# Altium schematic sheet Serial Monitor UI Processor Board Schematic.SchDoc("Serial Monitor UI Processor Board Schematic")
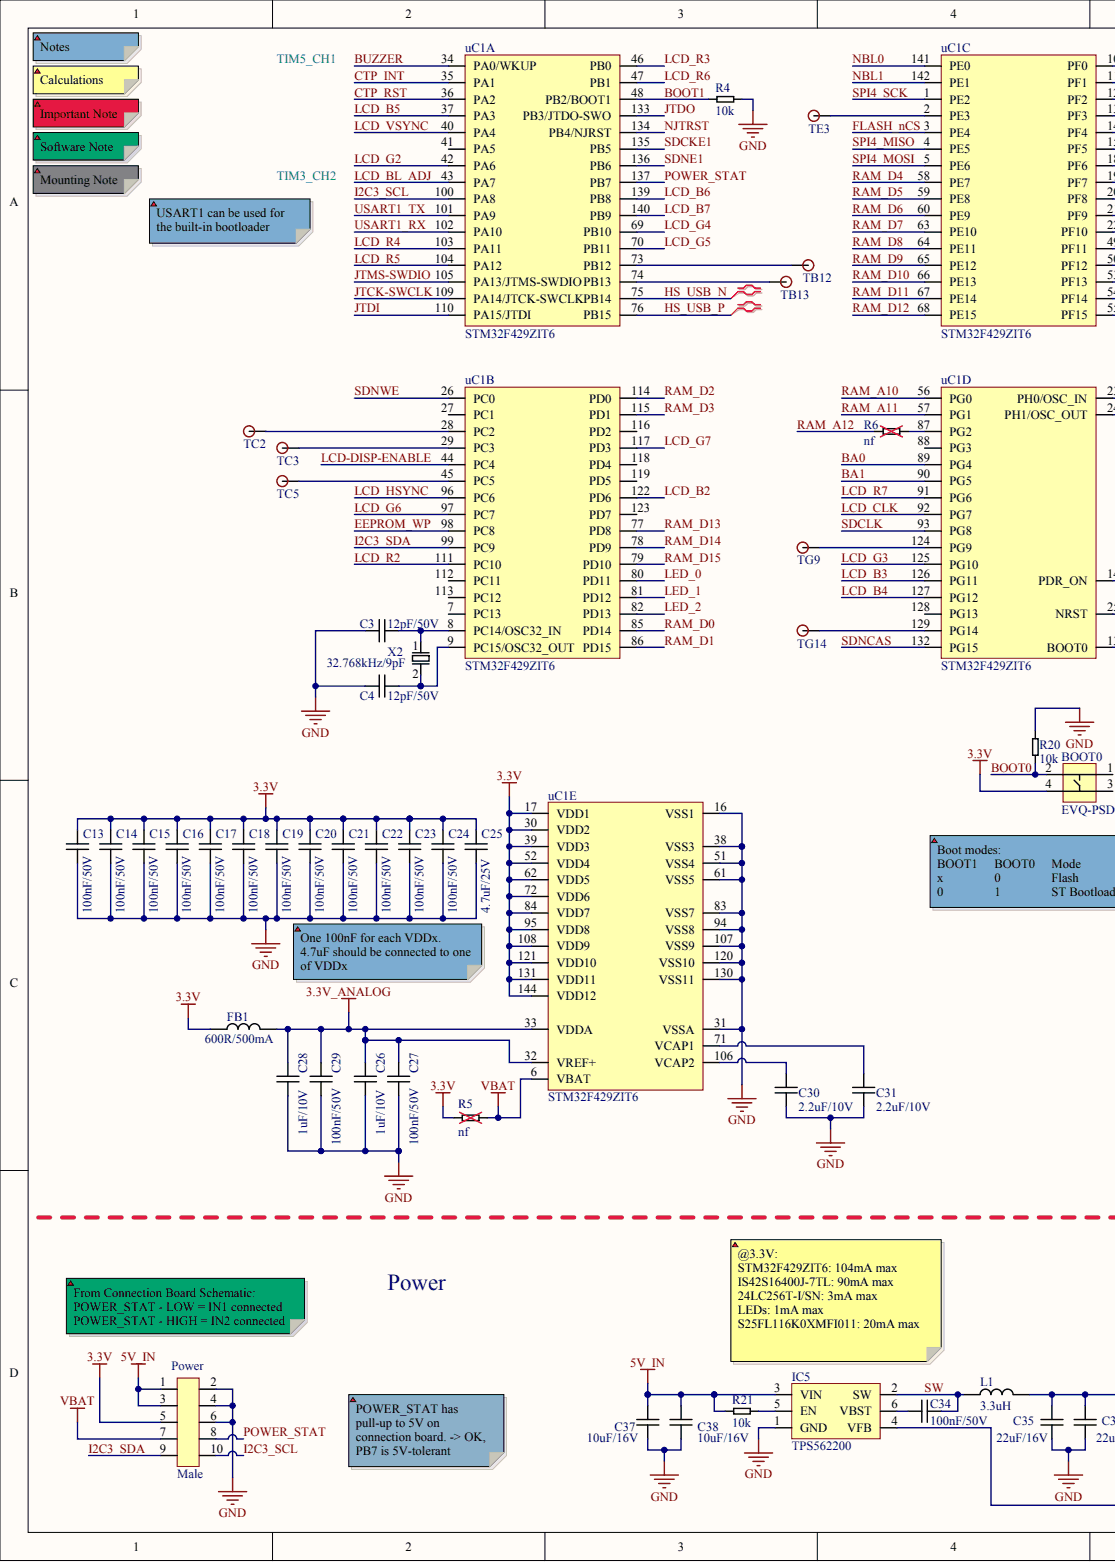
[diagram p0: Serial Monitor UI Processor Board Schematic.SchDoc, left]
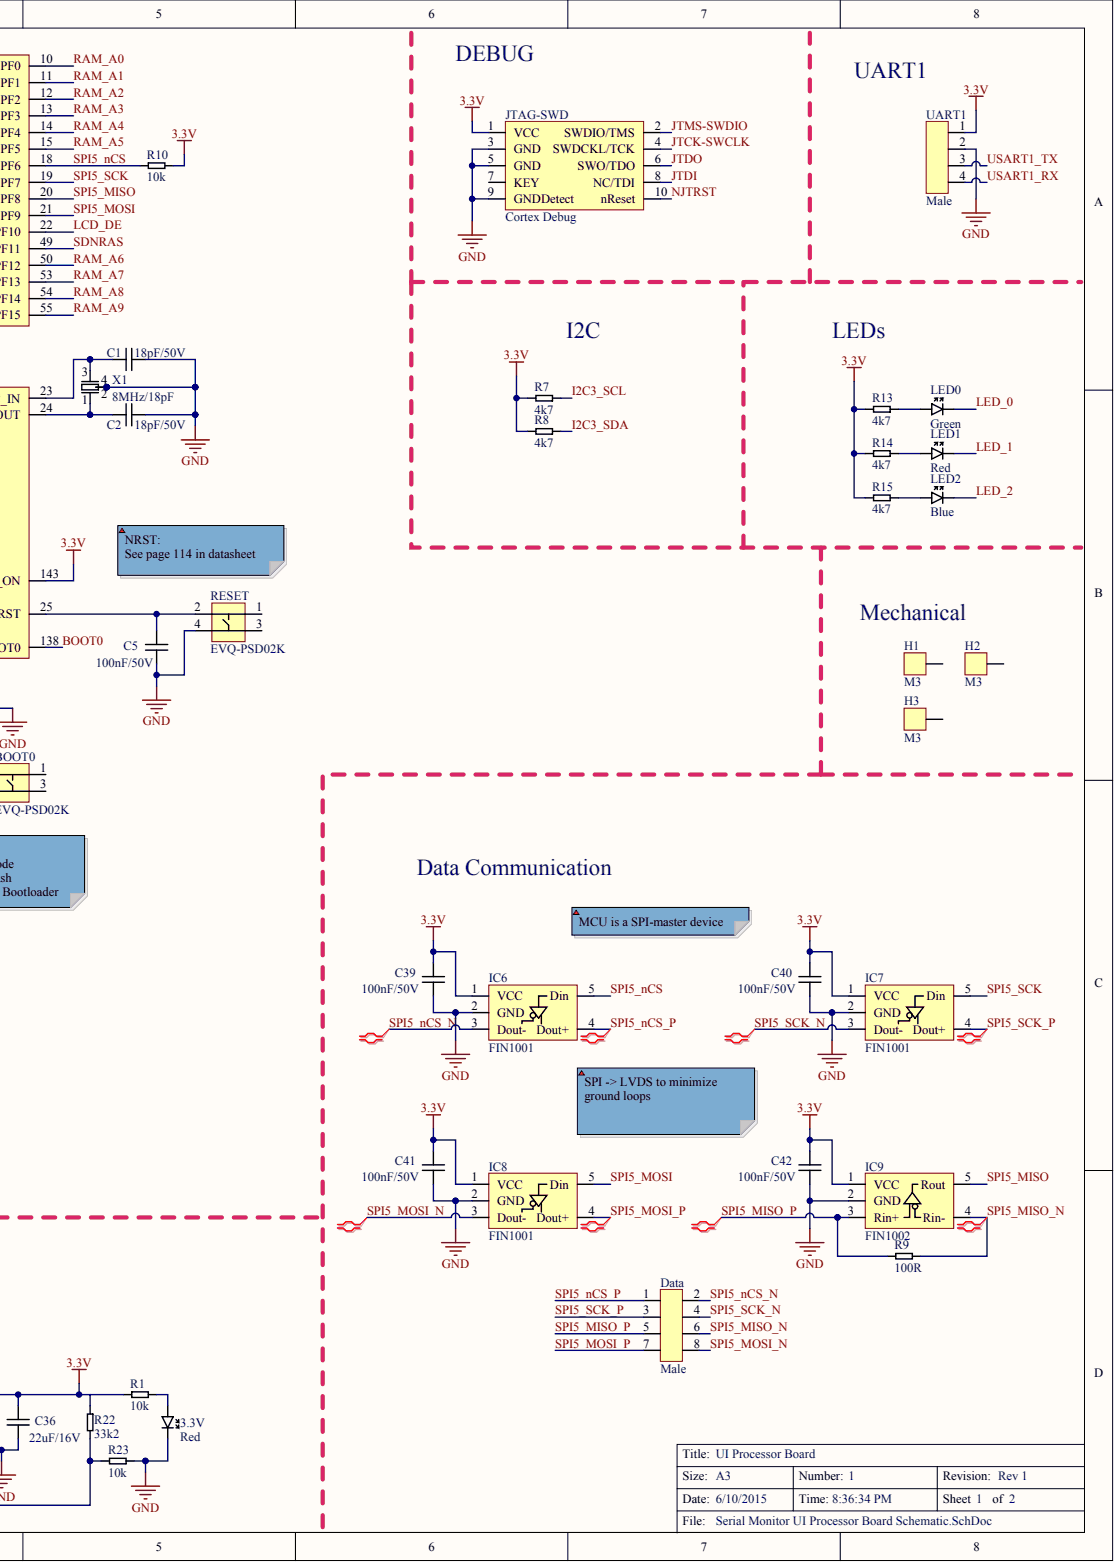
[diagram p1: Serial Monitor UI Processor Board Schematic.SchDoc, right]

## Parts
3.3V  value Red  (hidden comment: LED_0603_Red)
BOOT0  value EVQ-PSD02K  Part No.: EVQ-PSD02K  (hidden comment: Tact Switch EVQ-PSD02K)
C1  value 18pF/50V  [0603]  (hidden comment: Cap_0603_18pF/50V)
C2  value 18pF/50V  [0603]  (hidden comment: Cap_0603_18pF/50V)
C3  value 12pF/50V  [0603]  (hidden comment: Cap_0603_12pF/50V)
C4  value 12pF/50V  [0603]  (hidden comment: Cap_0603_12pF/50V)
C5  value 100nF/50V  [0603]  (hidden comment: Cap_0603_100nF/50V_X7R)
C13  value 100nF/50V  [0603]  (hidden comment: Cap_0603_100nF/50V_X7R)
C14  value 100nF/50V  [0603]  (hidden comment: Cap_0603_100nF/50V_X7R)
C15  value 100nF/50V  [0603]  (hidden comment: Cap_0603_100nF/50V_X7R)
C16  value 100nF/50V  [0603]  (hidden comment: Cap_0603_100nF/50V_X7R)
C17  value 100nF/50V  [0603]  (hidden comment: Cap_0603_100nF/50V_X7R)
C18  value 100nF/50V  [0603]  (hidden comment: Cap_0603_100nF/50V_X7R)
C19  value 100nF/50V  [0603]  (hidden comment: Cap_0603_100nF/50V_X7R)
C20  value 100nF/50V  [0603]  (hidden comment: Cap_0603_100nF/50V_X7R)
C21  value 100nF/50V  [0603]  (hidden comment: Cap_0603_100nF/50V_X7R)
C22  value 100nF/50V  [0603]  (hidden comment: Cap_0603_100nF/50V_X7R)
C23  value 100nF/50V  [0603]  (hidden comment: Cap_0603_100nF/50V_X7R)
C24  value 100nF/50V  [0603]  (hidden comment: Cap_0603_100nF/50V_X7R)
C25  value 4.7uF/25V  [0805]  (hidden comment: Cap_0805_4.7uF/25V)
C26  value 1uF/10V  [0603]  (hidden comment: Cap_0603_1uF/10V)
C27  value 100nF/50V  [0603]  (hidden comment: Cap_0603_100nF/50V_X7R)
C28  value 1uF/10V  [0603]  (hidden comment: Cap_0603_1uF/10V)
C29  value 100nF/50V  [0603]  (hidden comment: Cap_0603_100nF/50V_X7R)
C30  value 2.2uF/10V  [0805]  (hidden comment: Cap_0805_2.2uF/10V)
C31  value 2.2uF/10V  [0805]  (hidden comment: Cap_0805_2.2uF/10V)
C34  value 100nF/50V  [0603]  (hidden comment: Cap_0603_100nF/50V)
C35  value 22uF/16V  [0805]  (hidden comment: Cap_0805_22uF/16V)
C36  value 22uF/16V  [0805]  (hidden comment: Cap_0805_22uF/16V)
C37  value 10uF/16V  [0805]  (hidden comment: Cap_0805_10uF/16V)
C38  value 10uF/16V  [0805]  (hidden comment: Cap_0805_10uF/16V)
C39  value 100nF/50V  [0603]  (hidden comment: Cap_0603_100nF/50V)
C40  value 100nF/50V  [0603]  (hidden comment: Cap_0603_100nF/50V)
C41  value 100nF/50V  [0603]  (hidden comment: Cap_0603_100nF/50V)
C42  value 100nF/50V  [0603]  (hidden comment: Cap_0603_100nF/50V)
Data  value Male  (hidden comment: Connector 2x4)
FB1  value 600R/500mA  [0603]  (hidden comment: Ferrite_Bead_0603_600R_500mA)
H1  value M3  (hidden comment: M3_SCREWHOLE)
H2  value M3  (hidden comment: M3_SCREWHOLE)
H3  value M3  (hidden comment: M3_SCREWHOLE)
IC5  TPS562200  [SOT-23-6]
IC6  FIN1001  [SOT-23-5]
IC7  FIN1001  [SOT-23-5]
IC8  FIN1001  [SOT-23-5]
IC9  FIN1002  [SOT-23-5]
JTAG-SWD  value Cortex Debug  (hidden comment: Connector 2x5 Cortex Debug)
L1  value 3.3uH  [SMD SRN4028 4.8x4.8mm]  (hidden comment: Inductor_SRR4028_3.3uH)
LED0  value Green  (hidden comment: LED_0603_Green)
LED1  value Red  (hidden comment: LED_0603_Red)
LED2  value Blue  (hidden comment: LED_0603_Blue)
Power  value Male  (hidden comment: Connector 2x5)
R1  value 10k  [0603]  (hidden comment: Res_0603_10k)
R4  value 10k  [0603]  (hidden comment: Res_0603_10k)
R5  value nf  [0603]  (hidden comment: Res_0603_nf)
R6  value nf  [0603]  (hidden comment: Res_0603_nf)
R7  value 4k7  [0603]  (hidden comment: Res_0603_4k7)
R8  value 4k7  [0603]  (hidden comment: Res_0603_4k7)
R9  value 100R  [0603]  (hidden comment: Res_0603_100R)
R10  value 10k  [0603]  (hidden comment: Res_0603_10k)
R13  value 4k7  [0603]  (hidden comment: Res_0603_4k7)
R14  value 4k7  [0603]  (hidden comment: Res_0603_4k7)
R15  value 4k7  [0603]  (hidden comment: Res_0603_4k7)
R20  value 10k  [0603]  (hidden comment: Res_0603_10k)
R21  value 10k  [0603]  (hidden comment: Res_0603_10k)
R22  value 33k2  [0603]  (hidden comment: Res_0603_33k2)
R23  value 10k  [0603]  (hidden comment: Res_0603_10k)
RESET  value EVQ-PSD02K  Part No.: EVQ-PSD02K  (hidden comment: Tact Switch EVQ-PSD02K)
TB12  Testpad  (value not drawn)
TB13  Testpad  (value not drawn)
TC2  Testpad  (value not drawn)
TC3  Testpad  (value not drawn)
TC5  Testpad  (value not drawn)
TE3  Testpad  (value not drawn)
TG9  Testpad  (value not drawn)
TG14  Testpad  (value not drawn)
UART1  value Male  (hidden comment: Connector 1x4)
uC1  STM32F429ZIT6  [LQFP-144]  (parts A,B,C,D,E)
X1  value 8MHz/18pF  [4-pin SMD 5x3mm]  (hidden comment: 7B-8.000MAAJ-T)
X2  value 32.768kHz/9pF  [2-SMD 3.5x1.5mm]  (hidden comment: ABS07-32.768KHZ-9-T)

## Nets
3.3V: BOOT0.4 C13.2 C14.2 C15.2 C16.2 C17.2 C18.2 C19.2 C20.2 C21.2 C22.2 C23.2 C24.2 C25.2 C35.2 C36.2 C39.2 C40.2 C41.2 C42.2 FB1.1 IC6.1(VCC) IC7.1(VCC) IC8.1(VCC) IC9.1(VCC) JTAG-SWD.1(VCC) L1.2 Power.5 R1.2 R5.1 R7.1 R8.1 R10.1 R13.1 R14.1 R15.1 R22.2 UART1.1 uC1.17(VDD1) uC1.30(VDD2) uC1.39(VDD3) uC1.52(VDD4) uC1.62(VDD5) uC1.72(VDD6) uC1.84(VDD7) uC1.95(VDD8) uC1.108(VDD9) uC1.121(VDD10) uC1.131(VDD11) uC1.143(PDR_ON) uC1.144(VDD12)
3.3V_ANALOG: C26.2 C27.2 C28.2 C29.2 FB1.2 uC1.32(VREF+) uC1.33(VDDA)
5V_IN: C37.2 C38.2 IC5.3(VIN) Power.1 Power.3 R21.2
GND: 3.3V.CATHODE C1.2 C2.2 C3.2 C4.2 C5.1 C13.1 C14.1 C15.1 C16.1 C17.1 C18.1 C19.1 C20.1 C21.1 C22.1 C23.1 C24.1 C25.1 C26.1 C27.1 C28.1 C29.1 C30.1 C31.1 C35.1 C36.1 C37.1 C38.1 C39.1 C40.1 C41.1 C42.1 IC5.1(GND) IC6.2(GND) IC7.2(GND) IC8.2(GND) IC9.2(GND) JTAG-SWD.3(GND) JTAG-SWD.5(GND) JTAG-SWD.9(GNDDetect) Power.2 Power.4 Power.6 R4.2 R20.1 R23.2 RESET.4 UART1.2 uC1.16(VSS1) uC1.31(VSSA) uC1.38(VSS3) uC1.51(VSS4) uC1.61(VSS5) uC1.83(VSS7) uC1.94(VSS8) uC1.107(VSS9) uC1.120(VSS10) uC1.130(VSS11) X1.2 X1.4
VBAT: Power.7 R5.2 uC1.6(VBAT)
BA0: uC1.89(PG4)
BA1: uC1.90(PG5)
BOOT0: BOOT0.2 R20.2 uC1.138(BOOT0)
BOOT1: R4.1 uC1.48(PB2/BOOT1)
BUZZER: uC1.34(PA0/WKUP)
CTP_INT: uC1.35(PA1)
CTP_RST: uC1.36(PA2)
EEPROM_WP: uC1.98(PC8)
FLASH_NCS: uC1.3(PE4)
HS_USB_N: uC1.75(PB14)
HS_USB_P: uC1.76(PB15)
I2C3_SCL: Power.10 R7.2 uC1.100(PA8)
I2C3_SDA: Power.9 R8.2 uC1.99(PC9)
JTCK-SWCLK: JTAG-SWD.4(SWDCKL/TCK) uC1.109(PA14/JTCK-SWCLK)
JTDI: JTAG-SWD.8(NC/TDI) uC1.110(PA15/JTDI)
JTDO: JTAG-SWD.6(SWO/TDO) uC1.133(PB3/JTDO-SWO)
JTMS-SWDIO: JTAG-SWD.2(SWDIO/TMS) uC1.105(PA13/JTMS-SWDIO)
LCD-DISP-ENABLE: uC1.44(PC4)
LCD_B2: uC1.122(PD6)
LCD_B3: uC1.126(PG11)
LCD_B4: uC1.127(PG12)
LCD_B5: uC1.37(PA3)
LCD_B6: uC1.139(PB8)
LCD_B7: uC1.140(PB9)
LCD_BL_ADJ: uC1.43(PA7)
LCD_CLK: uC1.92(PG7)
LCD_DE: uC1.22(PF10)
LCD_G2: uC1.42(PA6)
LCD_G3: uC1.125(PG10)
LCD_G4: uC1.69(PB10)
LCD_G5: uC1.70(PB11)
LCD_G6: uC1.97(PC7)
LCD_G7: uC1.117(PD3)
LCD_HSYNC: uC1.96(PC6)
LCD_R2: uC1.111(PC10)
LCD_R3: uC1.46(PB0)
LCD_R4: uC1.103(PA11)
LCD_R5: uC1.104(PA12)
LCD_R6: uC1.47(PB1)
LCD_R7: uC1.91(PG6)
LCD_VSYNC: uC1.40(PA4)
LED_0: LED0.CATHODE uC1.80(PD11)
LED_1: LED1.CATHODE uC1.81(PD12)
LED_2: LED2.CATHODE uC1.82(PD13)
NBL0: uC1.141(PE0)
NBL1: uC1.142(PE1)
NJTRST: JTAG-SWD.10(nReset) uC1.134(PB4/NJRST)
POWER_STAT: Power.8 uC1.137(PB7)
RAM_A0: uC1.10(PF0)
RAM_A1: uC1.11(PF1)
RAM_A2: uC1.12(PF2)
RAM_A3: uC1.13(PF3)
RAM_A4: uC1.14(PF4)
RAM_A5: uC1.15(PF5)
RAM_A6: uC1.50(PF12)
RAM_A7: uC1.53(PF13)
RAM_A8: uC1.54(PF14)
RAM_A9: uC1.55(PF15)
RAM_A10: uC1.56(PG0)
RAM_A11: uC1.57(PG1)
RAM_A12: R6.1
RAM_D0: uC1.85(PD14)
RAM_D1: uC1.86(PD15)
RAM_D2: uC1.114(PD0)
RAM_D3: uC1.115(PD1)
RAM_D4: uC1.58(PE7)
RAM_D5: uC1.59(PE8)
RAM_D6: uC1.60(PE9)
RAM_D7: uC1.63(PE10)
RAM_D8: uC1.64(PE11)
RAM_D9: uC1.65(PE12)
RAM_D10: uC1.66(PE13)
RAM_D11: uC1.67(PE14)
RAM_D12: uC1.68(PE15)
RAM_D13: uC1.77(PD8)
RAM_D14: uC1.78(PD9)
RAM_D15: uC1.79(PD10)
SDCKE1: uC1.135(PB5)
SDCLK: uC1.93(PG8)
SDNCAS: uC1.132(PG15)
SDNE1: uC1.136(PB6)
SDNRAS: uC1.49(PF11)
SDNWE: uC1.26(PC0)
SPI4_MISO: uC1.4(PE5)
SPI4_MOSI: uC1.5(PE6)
SPI4_SCK: uC1.1(PE2)
SPI5_MISO: IC9.5(Rout) uC1.20(PF8)
SPI5_MISO_N: Data.6 IC9.4(Rin-) R9.1
SPI5_MISO_P: Data.5 IC9.3(Rin+) R9.2
SPI5_MOSI: IC8.5(Din) uC1.21(PF9)
SPI5_MOSI_N: Data.8 IC8.3(Dout-)
SPI5_MOSI_P: Data.7 IC8.4(Dout+)
SPI5_NCS: IC6.5(Din) R10.2 uC1.18(PF6)
SPI5_NCS_N: Data.2 IC6.3(Dout-)
SPI5_NCS_P: Data.1 IC6.4(Dout+)
SPI5_SCK: IC7.5(Din) uC1.19(PF7)
SPI5_SCK_N: Data.4 IC7.3(Dout-)
SPI5_SCK_P: Data.3 IC7.4(Dout+)
SW: C34.2 IC5.2(SW) L1.1
USART1_RX: UART1.4 uC1.102(PA10)
USART1_TX: UART1.3 uC1.101(PA9)
Net3.3V_ANODE: 3.3V.ANODE R1.1
NetC1_1: C1.1 uC1.23(PH0/OSC_IN) X1.3
NetC2_1: C2.1 uC1.24(PH1/OSC_OUT) X1.1
NetC3_1: C3.1 uC1.8(PC14/OSC32_IN) X2.1
NetC4_1: C4.1 uC1.9(PC15/OSC32_OUT) X2.2
NetC5_2: C5.2 RESET.2 uC1.25(NRST)
NetC30_2: C30.2 uC1.106(VCAP2)
NetC31_2: C31.2 uC1.71(VCAP1)
NetC34_1: C34.1 IC5.6(VBST)
NetIC5_4: IC5.4(VFB) R22.1 R23.1
NetIC5_5: IC5.5(EN) R21.1
NetLED0_ANODE: LED0.ANODE R13.2
NetLED1_ANODE: LED1.ANODE R14.2
NetLED2_ANODE: LED2.ANODE R15.2
NetR6_2: R6.2 uC1.87(PG2)
NetTB12_1: TB12.1 uC1.73(PB12)
NetTB13_1: TB13.1 uC1.74(PB13)
NetTC2_1: TC2.1 uC1.28(PC2)
NetTC3_1: TC3.1 uC1.29(PC3)
NetTC5_1: TC5.1 uC1.45(PC5)
NetTE3_1: TE3.1 uC1.2(PE3)
NetTG9_1: TG9.1 uC1.124(PG9)
NetTG14_1: TG14.1 uC1.129(PG14)

Not connected: BOOT0 1 3; H1 HOLE; H2 HOLE; H3 HOLE; JTAG-SWD 7(KEY); RESET 1 3; uC1 7(PC13) 27(PC1) 41(PA5) 88(PG3) 112(PC11) 113(PC12) 116(PD2) 118(PD4) 119(PD5) 123(PD7) 128(PG13)

## Library data of the parts
3.3V = (no symbol name): Part No.: APT1608SRCPRV; Manufacturer: Kingbright; RS: 654-5420; DigiKey: 754-1122-1-ND; Price SEK (No VAT): 1; Mouser: 604-APT1608SRCPRV
BOOT0 RESET = (no symbol name): Manufacturer: Panasonic; Mouser: 667-EVQ-PSD02K; DigiKey: P8088SCT-ND; Price SEK (No VAT): 6; Datasheet: http://industrial.panasonic.com/www-data/pdf/ATV0000/ATV0000CE10.pdf
C1 C2 = (no symbol name): Part No.: C1608C0G1H180J080AA; Manufacturer: TDK; RS: 788-2944 (50-pack); Price SEK (No VAT): 0,3; DigiKey: 445-1272-1-ND; Mouser: 810-C1608C0G1H180J; Farnell: 1907287
C3 C4 = (no symbol name): Part No.: C1608C0G1H120J080AA; Manufacturer: TDK; RS: 788-2931 (50-pack); DigiKey: 445-1270-1-ND; Price SEK (No VAT): 0,3; Mouser: 810-C1608C0G1H120J; Farnell: 1907285
C5 C13-C24 C27 C29 C34 C39-C42 = (no symbol name): Part No.: C1608X7R1H104K080AA; Manufacturer: TDK; RS: 741-4459 (500-pack); DigiKey: 445-1314-1-ND; Mouser: 810-C1608X7R1H104K; Price SEK (No VAT): 0,2
C25 = (no symbol name): Part No.: GRM21BR61E475KA12L; Manufacturer: Murata; DigiKey: 490-3335-1-ND; Mouser: 81-GRM21BR61E475KA12; Price SEK (No VAT): 1
C26 C28 = (no symbol name): Part No.: C1608X5R1A105K080AC; Manufacturer: TDK; Mouser: 810-C1608X5R1A105K; Price SEK (No VAT): 1; DigiKey: 445-1321-1-ND
C30 C31 = (no symbol name): Part No.: GRM21BR71A225KA01L; Manufacturer: Murata; Mouser: 81-GRM21BR71A225KA01; DigiKey: 490-1696-1-ND; Price SEK (No VAT): 1
C35 C36 = (no symbol name): Part No.: C2012X5R1C226M125AC; Manufacturer: Murata; DigiKey: 445-7647-1-ND; Mouser: 810-C2012X5R1C226M; Price SEK (No VAT): 6
C37 C38 = (no symbol name): Part No.: GRM21BR61C106KE15L; Manufacturer: Murata; DigiKey: 490-3886-1-ND; Price SEK (No VAT): 1,5; Mouser: 81-GRM21BR61C106KE15
FB1 = (no symbol name): Part No.: MMZ1608B601C; Manufacturer: TDK; RS: 786-5015; Price SEK (No VAT): 1; Mouser: 810-MMZ1608B601C; DigiKey: 445-2166-1-ND; Farnell: 1669687
IC5 = (no symbol name): Part No.: TPS562200DDCR; Manufacturer: TI; DigiKey: 296-39631-1-ND; Price SEK (No VAT): 12; Mouser: 595-TPS562200DDCR; RS: *; Value: TPS562200
IC6-IC8 = (no symbol name): Part No.: FIN1001M5X; Manufacturer: Fairchild; RS: 807-0791 (10-pack); DigiKey: FIN1001M5XCT-ND; Price SEK (No VAT): 9; Mouser: 512-FIN1001M5X; Farnell: 1467289; Value: FIN1001
IC9 = (no symbol name): Part No.: FIN1002M5X; Manufacturer: Fairchild; RS: 807-0795 (10-pack); DigiKey: FIN1002M5XCT-ND; Price SEK (No VAT): 9; Mouser: 512-FIN1002M5X; Farnell: 1467290; Value: FIN1002
L1 = (no symbol name): Part No.: SRR4028-3R3Y; Manufacturer: Bourns; RS: 727-2022 (5-pack); Mouser: 652-SRR4028-3R3Y; Price SEK (No VAT): 6; DigiKey: SRR4028-3R3YCT-ND; Farnell: *
LED0 = (no symbol name): Part No.: APT1608CGCK; Manufacturer: Kingbright; Mouser: 604-APT1608CGCK; Price SEK (No VAT): 1; DigiKey: 754-1116-1-ND
LED1 = (no symbol name): Part No.: APT1608SRCPRV; Manufacturer: Kingbright; Value: Red; RS: 654-5420; DigiKey: 754-1122-1-ND; Price SEK (No VAT): 1; Mouser: 604-APT1608SRCPRV
LED2 = (no symbol name): Part No.: APT1608QBC/D; Manufacturer: Kingbright; DigiKey: 754-1434-1-ND; Price SEK (No VAT): 2; Mouser: 604-APT1608QBC/D
R1 R4 R7 R8 R10 R13-R15 R20 R21 R23 = (no symbol name): Part No.: CRCW060310K0FKEA; Manufacturer: Vishay; Price SEK (No VAT): 0,1; Mouser: 71-CRCW0603-10K-E3; DigiKey: 541-10.0KHCT-ND
R9 = (no symbol name): Part No.: CRCW0603100RFKEA; Manufacturer: Vishayp; Price SEK (No VAT): 0,1; Mouser: 71-CRCW0603-100-E3; DigiKey: 541-100HCT-ND
R22 = (no symbol name): Part No.: CRCW060333K2FKEA; Manufacturer: Vishay; Price SEK (No VAT): 0,1; Mouser: 71-CRCW0603-33.2K-E3; DigiKey: 541-33.2KHCT-ND
uC1 = (no symbol name): Manufacturer: ST; Part No.: STM32F429ZIT6; Mouser: 511-STM32F429ZIT6; DigiKey: 497-14053-ND; Price SEK (No VAT): 159; Value: STM32F429ZIT6
X1 = (no symbol name): Manufacturer: TXC; Part No.: 7B-8.000MAAJ-T; Mouser: 717-7B-8.000MAAJ-T; DigiKey: 887-1452-1-ND; Price SEK (No VAT): 8
X2 = (no symbol name): Manufacturer: Abracon; Part No.: ABS07-32.768KHZ-9-T; Mouser: 815-ABS07-32.768K9T; DigiKey: 535-9544-1-ND; Price SEK (No VAT): 14
Data H1-H3 JTAG-SWD Power R5 R6 TB12 TB13 TC2 TC3 TC5 TE3 TG9 TG14 UART1 = (no symbol name)
  R5 R6: Price SEK (No VAT): 0
